# Altium schematic sheet Implausibility_Logic.SchDoc(Implausibility_Logic)
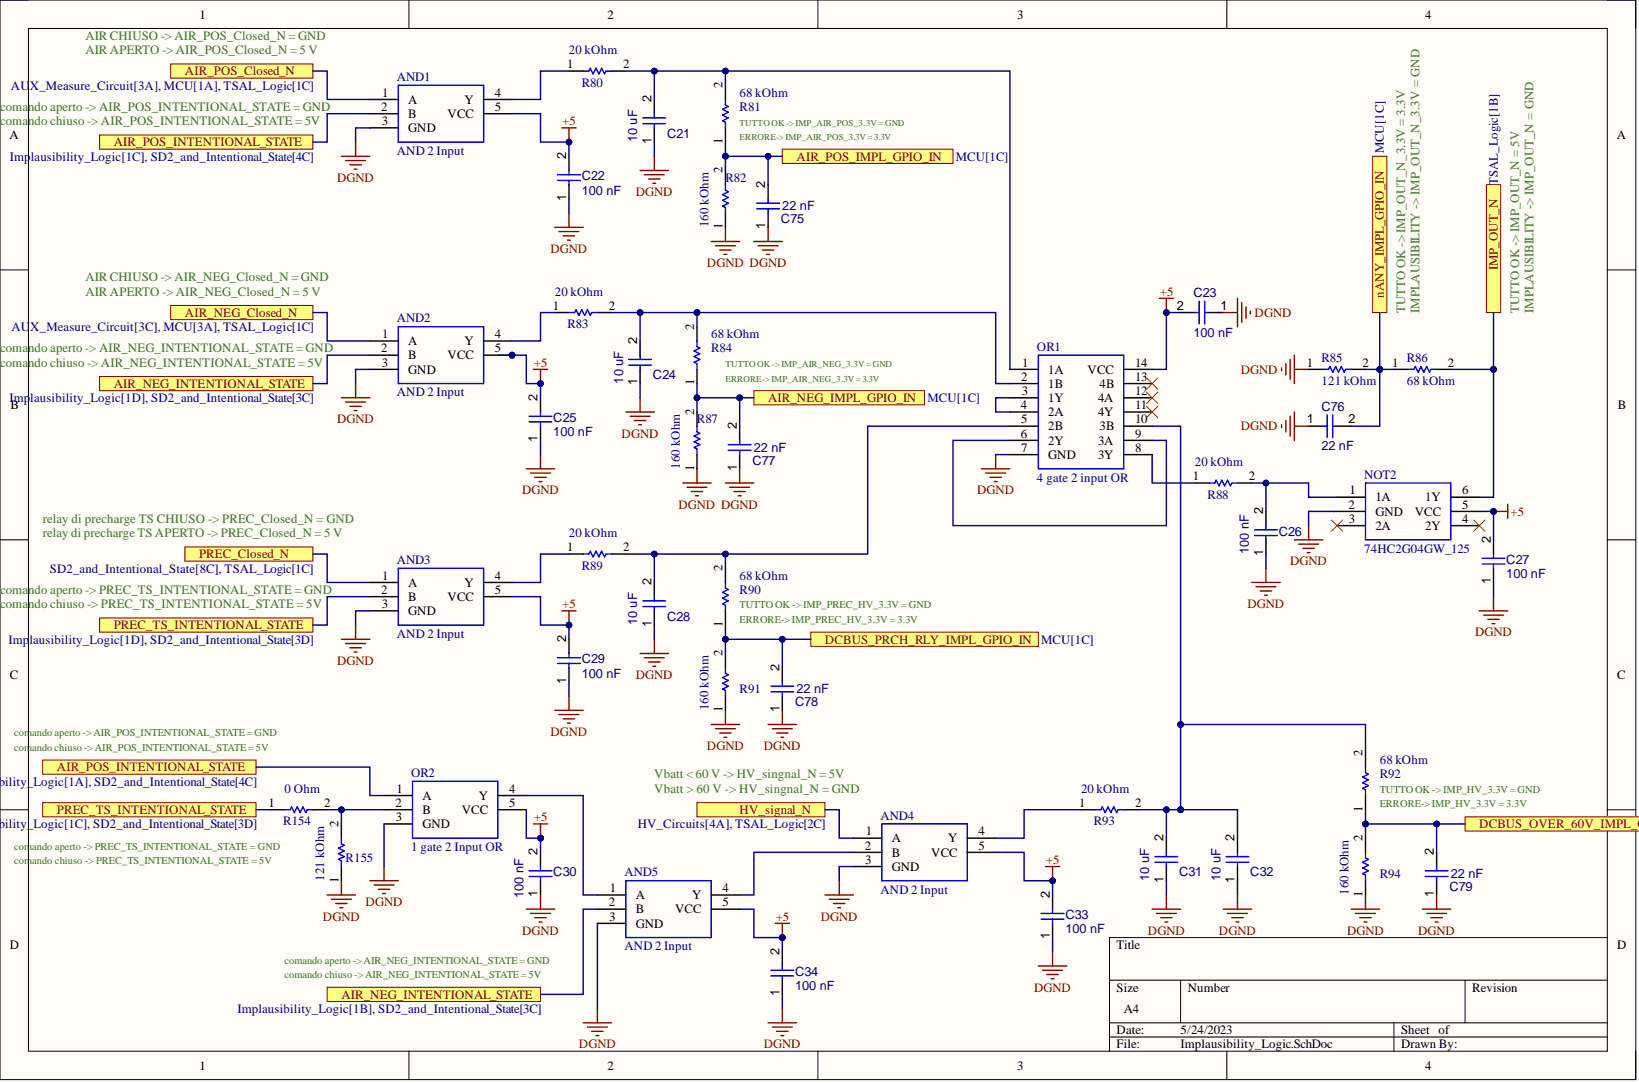
[diagram p0: Implausibility_Logic.SchDoc]

## Parts
AND1  AND 2 Input
AND2  AND 2 Input
AND3  AND 2 Input
AND4  AND 2 Input
AND5  AND 2 Input
C21  10 uF
C22  100 nF
C23  100 nF
C24  10 uF
C25  100 nF
C26  100 nF
C27  100 nF
C28  10 uF
C29  100 nF
C30  100 nF
C31  10 uF
C32  10 uF
C33  100 nF
C34  100 nF
C75  22 nF
C76  22 nF
C77  22 nF
C78  22 nF
C79  22 nF
NOT2  74HC2G04GW_125
OR1  4 gate 2 input OR
OR2  1 gate 2 Input OR
R80  20 kOhm
R81  68 kOhm
R82  160 kOhm
R83  20 kOhm
R84  68 kOhm
R85  121 kOhm
R86  68 kOhm
R87  160 kOhm
R88  20 kOhm
R89  20 kOhm
R90  68 kOhm
R91  160 kOhm
R92  68 kOhm
R93  20 kOhm
R94  160 kOhm
R154  0 Ohm
R155  121 kOhm

## Ports
AIR_NEG_Closed_N, AIR_NEG_IMPL_GPIO_IN, AIR_NEG_INTENTIONAL_STATE, AIR_POS_Closed_N, AIR_POS_IMPL_GPIO_IN, AIR_POS_INTENTIONAL_STATE, DCBUS_OVER_60V_IMPL_GPIO_IN, DCBUS_PRCH_RLY_IMPL_GPIO_IN, HV_signal_N, IMP_OUT_N, nANY_IMPL_GPIO_IN, PREC_Closed_N, PREC_TS_INTENTIONAL_STATE

## Nets
+5: AND1.5(VCC) AND2.5(VCC) AND3.5(VCC) AND4.5(VCC) AND5.5(VCC) C22.2 C23.2 C25.2 C27.2 C29.2 C30.2 C33.2 C34.2 NOT2.5(VCC) OR1.14(VCC) OR2.5(VCC)
DGND: AND1.3(GND) AND2.3(GND) AND3.3(GND) AND4.3(GND) AND5.3(GND) C21.1 C22.1 C23.1 C24.1 C25.1 C26.1 C27.1 C28.1 C29.1 C30.1 C31.1 C32.1 C33.1 C34.1 C75.1 C76.1 C77.1 C78.1 C79.1 NOT2.2(GND) OR1.7(GND) OR2.3(GND) R82.1 R85.1 R87.1 R91.1 R94.1 R155.1
AIR_NEG_Closed_N: AND2.1(A) ; port AIR_NEG_Closed_N
AIR_NEG_IMPL_GPIO_IN: C77.2 R84.1 R87.2 ; port AIR_NEG_IMPL_GPIO_IN
AIR_NEG_INTENTIONAL_STATE: AND2.2(B) AND5.2(B) ; port AIR_NEG_INTENTIONAL_STATE
AIR_POS_Closed_N: AND1.1(A) ; port AIR_POS_Closed_N
AIR_POS_IMPL_GPIO_IN: C75.2 R81.1 R82.2 ; port AIR_POS_IMPL_GPIO_IN
AIR_POS_INTENTIONAL_STATE: AND1.2(B) OR2.1(A) ; port AIR_POS_INTENTIONAL_STATE
DCBUS_OVER_60V_IMPL_GPIO_IN: C79.2 R92.1 R94.2 ; port DCBUS_OVER_60V_IMPL_GPIO_IN
DCBUS_PRCH_RLY_IMPL_GPIO_IN: C78.2 R90.1 R91.2 ; port DCBUS_PRCH_RLY_IMPL_GPIO_IN
HV_signal_N: AND4.1(A) ; port HV_signal_N
IMP_OUT_N: NOT2.6(1Y) R86.2 ; port IMP_OUT_N
nANY_IMPL_GPIO_IN: C76.2 R85.2 R86.1 ; port nANY_IMPL_GPIO_IN
PREC_Closed_N: AND3.1(A) ; port PREC_Closed_N
PREC_TS_INTENTIONAL_STATE: AND3.2(B) R154.1 ; port PREC_TS_INTENTIONAL_STATE
NetAND1_4: AND1.4(Y) R80.1
NetAND2_4: AND2.4(Y) R83.1
NetAND3_4: AND3.4(Y) R89.1
NetAND4_2: AND4.2(B) AND5.4(Y)
NetAND4_4: AND4.4(Y) R93.1
NetAND5_1: AND5.1(A) OR2.4(Y)
NetC21_2: C21.2 OR1.1(1A) R80.2 R81.2
NetC24_2: C24.2 OR1.2(1B) R83.2 R84.2
NetC26_2: C26.2 NOT2.1(1A) R88.2
NetC28_2: C28.2 OR1.5(2B) R89.2 R90.2
NetC31_2: C31.2 C32.2 OR1.10(3B) R92.2 R93.2
NetOR1_3: OR1.3(1Y) OR1.4(2A)
NetOR1_6: OR1.6(2Y) OR1.9(3A)
NetOR1_8: OR1.8(3Y) R88.1
NetOR2_2: OR2.2(B) R154.2 R155.2

Not connected: NOT2 3(2A) 4(2Y); OR1 11(4Y) 12(4A) 13(4B)

## Library data of the parts
AND1-AND5 = CMP-059-000000-1: SN74AHC1G08DBVR; Manufacturer_Name: Texas Instruments; Manufacturer_Part_Number: SN74AHC1G08DBVT; Geometry.Height: 1.45mm; Component Kind: Standard; ComponentLink1Description: Website; ComponentLink1URL: https://www.mouser.it/ProductDetail/Texas-Instruments/SN74AHC1G08DBVT?qs=fSCvMSq5ISWy2nKdHbDECw%3D%3D; Datasheet Link: http://www.ti.com/lit/gpn/SN74AHC1G08; Design Item ID: SN74AHC1G08DBVT; Mouser Part Number: 595-SN74AHC1G08DBVT; Mouser Price/Stock: https://www.mouser.co.uk/ProductDetail/Texas-Instruments/SN74AHC1G08DBVT?qs=fSCvMSq5ISWy2nKdHbDECw%3D%3D; Source: SN74AHC1G08DBVT.SchLib; Library Name: EMEA- Squadra Corse PoliTO-  Politecnico di Torino
C21 C24 C28 C31 C32 = CMP-001-00085-2: GRM21BR71A106KA73K; Tech: SMD; Component Kind: Standard; ComponentLink1Description: Website; ComponentLink1URL: https://www.mouser.it/ProductDetail/Vishay-Vitramon/VJ0805G106MXQTW1BC?qs=PqoDHHvF64%2FiIFVCZpIM5w%3D%3D; Package: 0805; Library Name: EMEA- Squadra Corse PoliTO-  Politecnico di Torino
C22 C23 C25-C27 C29 C30 C33 C34 = CMP-001-00085-2: VJ0805Y104MXATW1BC; Tech: SMD; Component Kind: Standard; ComponentLink1Description: Website; ComponentLink1URL: https://www.mouser.it/ProductDetail/KYOCERA-AVX/08055C104KAT4A?qs=2ujN4bDBNE8aFT7CFyBStg%3D%3D; Package: 0805; Library Name: EMEA- Squadra Corse PoliTO-  Politecnico di Torino
C75-C79 = CMP-001-00085-2: VJ0805Y223JXACW1BC; Tech: SMD; Component Kind: Standard; ComponentLink1Description: Website; ComponentLink1URL: https://www.mouser.it/ProductDetail/Vishay-Vitramon/VJ0805Y223JXXPW1BC?qs=dYENeDlLSFWEZ4cdRF5phA%3D%3D; Package: 0805; Library Name: EMEA- Squadra Corse PoliTO-  Politecnico di Torino
NOT2 = CMP-071-000000-2: NOT 2 CIRCUITS; Tech: SMD; Component Kind: Standard; ComponentLink1Description: Website; ComponentLink1URL: https://www.mouser.it/ProductDetail/Nexperia/74HC2G04GW125?qs=P62ublwmbi8EHFb4JRBQoA%3D%3D; Package: TSSOP-6; Library Name: EMEA- Squadra Corse PoliTO-  Politecnico di Torino
OR1 = CMP-073-000000-2: SN74AHC32DE4; Tech: SMD; Component Kind: Standard; ComponentLink1Description: Website; ComponentLink1URL: https://www.mouser.it/ProductDetail/Texas-Instruments/SN74AHC32DE4?qs=pdM4geFiyZRVMA0YMu8u4w%3D%3D; Package: SOIC-14; Library Name: EMEA- Squadra Corse PoliTO-  Politecnico di Torino
OR2 = CMP-002-000003-1: SN74AHC1G32DBVT; Manufacturer_Name: Texas Instruments; Geometry.Height: 1.45mm; Component Kind: Standard; ComponentLink1Description: Website; ComponentLink1URL: https://www.mouser.it/ProductDetail/Texas-Instruments/SN74AHC1G32DBVT?qs=fSCvMSq5ISVX4j%2FpWgAWfA%3D%3D; Datasheet Link: http://www.ti.com/general/docs/lit/getliterature.tsp?genericPartNumber=sn74ahc1g32&fileType=pdf; Design Item ID: SN74AHC1G32DBVT; Manufacturer_Part_Number: SN74AHC1G32DBVT; Mouser Part Number: 595-SN74AHC1G32DBVT; Mouser Price/Stock: https://www.mouser.co.uk/ProductDetail/Texas-Instruments/SN74AHC1G32DBVT?qs=fSCvMSq5ISVX4j%2FpWgAWfA%3D%3D; Source: SN74AHC1G32DBVT.SchLib; Library Name: EMEA- Squadra Corse PoliTO-  Politecnico di Torino
R80 R83 R88 R89 R93 = CMP-009-00179-4: CRCW060320K0FKEAC; Tech: SMD; Component Kind: Standard; ComponentLink1Description: Website; ComponentLink1URL: https://www.mouser.it/ProductDetail/Vishay-Dale/CRCW060320K0FKEB?qs=%252BZOPz%252B2NLYVQrVjDVZxTrA%3D%3D; Package: 0603; Library Name: EMEA- Squadra Corse PoliTO-  Politecnico di Torino
R81 R84 R86 R90 R92 = CMP-009-00179-4: CRCW060368K0FKEAC; Tech: SMD; Component Kind: Standard; ComponentLink1Description: Website; ComponentLink1URL: https://www.mouser.it/ProductDetail/Vishay-Dale/CRCW060368K0FKEAC?qs=E3Y5ESvWgWOWm3dIamBggg%3D%3D; Package: 0603; Library Name: EMEA- Squadra Corse PoliTO-  Politecnico di Torino
R82 R87 R91 R94 = CMP-009-00179-4: CRCW0603160KFKEA; Tech: SMD; Component Kind: Standard; ComponentLink1Description: Website; ComponentLink1URL: https://www.mouser.it/ProductDetail/Vishay-Dale/CRCW0603160KFKEA?qs=tDXmwIWkiJmFFQSyWZDROA%3D%3D; Package: 0603; Library Name: EMEA- Squadra Corse PoliTO-  Politecnico di Torino
R85 R155 = CMP-009-00179-4: CRCW0603121KFKEA; Tech: SMD; Component Kind: Standard; ComponentLink1Description: Website; ComponentLink1URL: https://www.mouser.it/ProductDetail/Vishay-Dale/CRCW0603121KFKEA?qs=SNwsiWikH8rxaNKbUsRIDA%3D%3D; Package: 0603; Library Name: EMEA- Squadra Corse PoliTO-  Politecnico di Torino
R154 = CMP-009-00179-4: CRCW06030000Z0EAHP; Tech: SMD; Component Kind: Standard; ComponentLink1Description: Website; ComponentLink1URL: https://www.mouser.it/ProductDetail/Vishay-Dale/CRCW06030000Z0EAHP?qs=pTGEtN%252BMLlBR2wfI1qu2Ng%3D%3D; Package: 0603; Library Name: EMEA- Squadra Corse PoliTO-  Politecnico di Torino
